# Altium schematic sheet Yamper.SchDoc
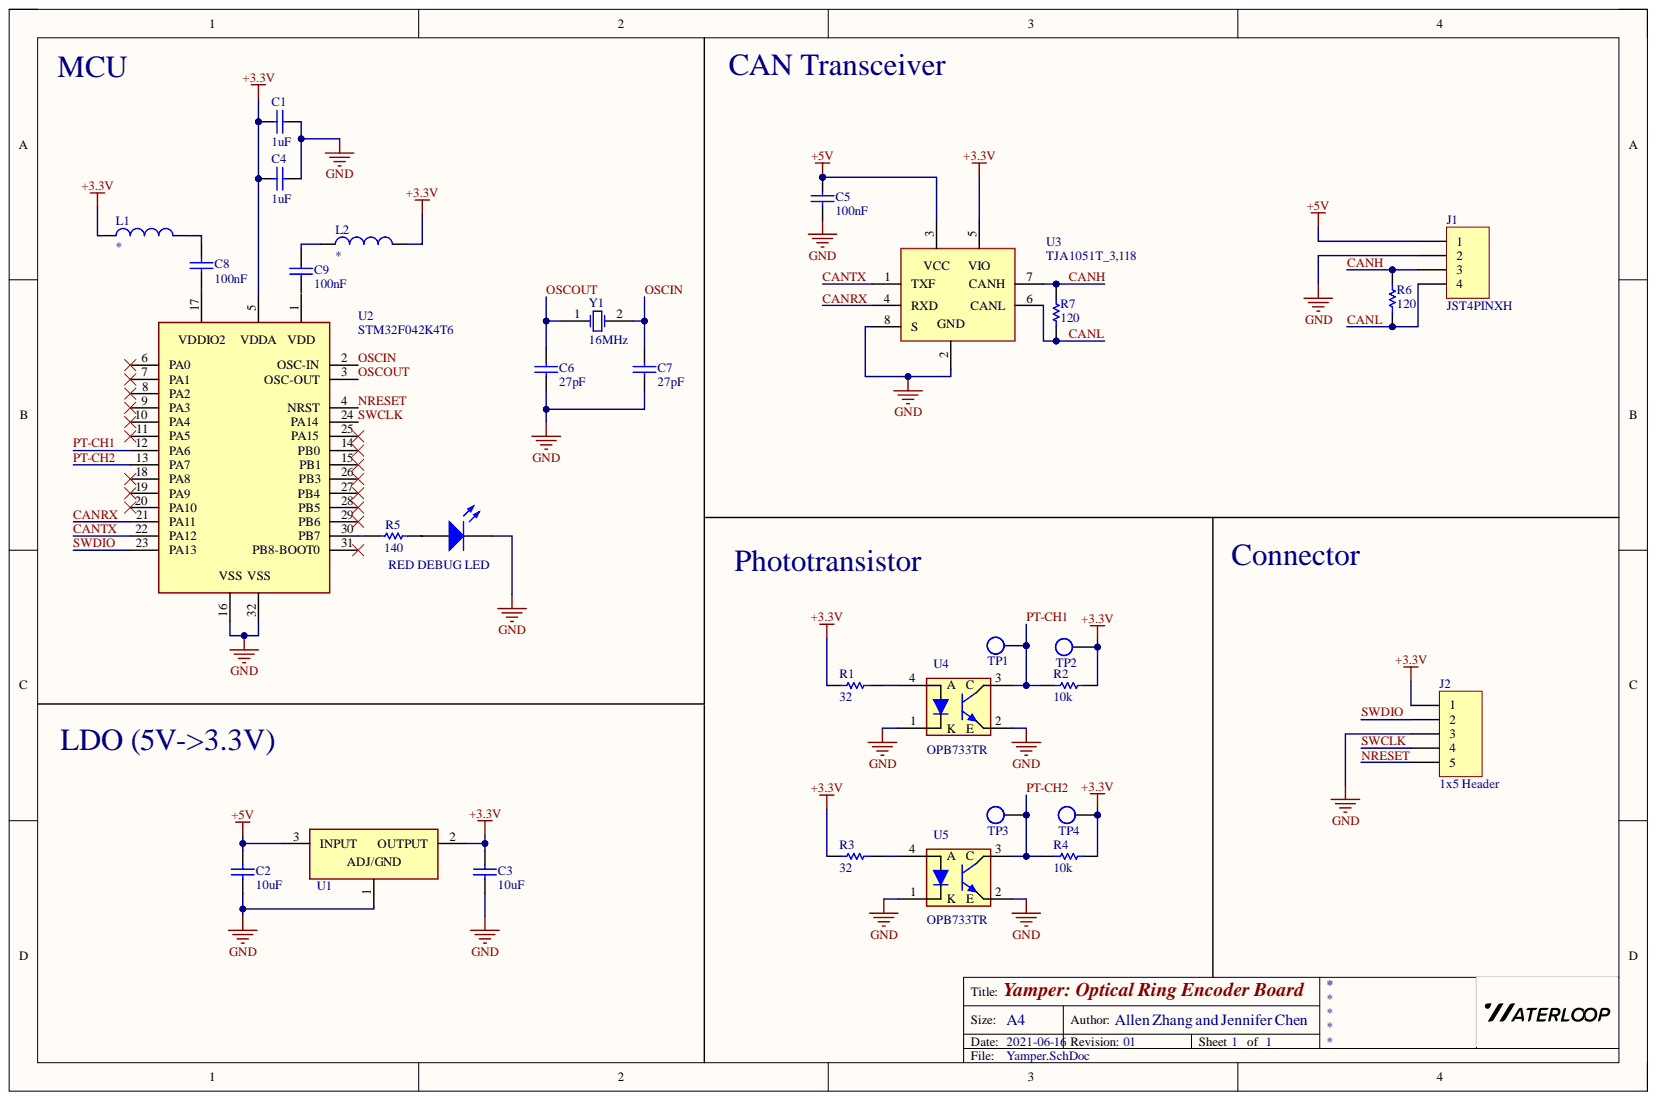
[diagram p0: Yamper.SchDoc]

## Parts
C1  value 1uF  [0603 - Capacitor]  (hidden comment: Capacitor - Nonpolarized)
C2  value 10uF  [0603 - Capacitor]  (hidden comment: Capacitor - Nonpolarized)
C3  value 10uF  [0603 - Capacitor]  (hidden comment: Capacitor - Nonpolarized)
C4  value 1uF  [0603 - Capacitor]  (hidden comment: Capacitor - Nonpolarized)
C5  value 100nF  [0603 - Capacitor]  (hidden comment: Capacitor - Nonpolarized)
C6  value 27pF  [0603 - Capacitor]  (hidden comment: Capacitor - Nonpolarized)
C7  value 27pF  [0603 - Capacitor]  (hidden comment: Capacitor - Nonpolarized)
C8  value 100nF  [0603 - Capacitor]  (hidden comment: Capacitor - Nonpolarized)
C9  value 100nF  [0603 - Capacitor]  (hidden comment: Capacitor - Nonpolarized)
J1  JST4PINXH  [HDR1X4]
J2  1x5 Header  [HDR1X5]
L1  Inductor  [MSS1210 - INDUCTOR]  (value not drawn)
L2  Inductor  [MSS1210 - INDUCTOR]  (value not drawn)
R1  value 32  [0603 - Resistor]  (hidden comment: Resistor)
R2  value 10k  [0603 - Resistor]  (hidden comment: Resistor)
R3  value 32  [0603 - Resistor]  (hidden comment: Resistor)
R4  value 10k  [0603 - Resistor]  (hidden comment: Resistor)
R5  value 140  [0603 - Resistor]  (hidden comment: Resistor)
R6  value 120  [0603 - Resistor]  (hidden comment: Resistor)
R7  value 120  [0603 - Resistor]  (hidden comment: Resistor)
RED_DEBUG_LED  LED
TP1  Test Point  [Test Point]  (value not drawn)  (no BOM)
TP2  Test Point  [Test Point]  (value not drawn)  (no BOM)
TP3  Test Point  [Test Point]  (value not drawn)  (no BOM)
TP4  Test Point  [Test Point]  (value not drawn)  (no BOM)
U1  TLV1117-33IDCYR  [TLV1117]  (value not drawn)
U2  STM32F042K4T6  [QFP80P900X900X160-32N]
U3  TJA1051T_3,118  [TJA1051T/3,118]
U4  OPB733TR
U5  OPB733TR
Y1  value 16MHz  [2-SMD NO LEAD CRSYSTAL]  (hidden comment: Oscillator)

## Nets
+3.3V: C1.1 C3.2 C4.1 J2.1 L1.1 L2.2 R1.1 R2.2 R3.1 R4.2 TP2.1 TP4.1 U1.2 U2.5 U3.5
+5V: C2.1 C5.2 J1.1 U1.3 U3.3
GND: C1.2 C2.2 C3.1 C4.2 C5.1 C6.1 C7.1 J1.2 J2.3 RED_DEBUG_LED.2 U1.1 U2.16 U2.32 U3.2 U3.8(S) U4.1(K) U4.2(E) U5.1(K) U5.2(E)
CANH: J1.3 R6.2 R7.2 U3.7(CANH)
CANL: J1.4 R6.1 R7.1 U3.6(CANL)
CANRX: U2.21(PA11) U3.4(RXD)
CANTX: U2.22(PA12) U3.1(TXF)
NRESET: J2.5 U2.4(NRST)
OSCIN: C7.2 U2.2(OSC-IN) Y1.2
OSCOUT: C6.2 U2.3(OSC-OUT) Y1.1
PT-CH1: R2.1 TP1.1 U2.12(PA6) U4.3(C)
PT-CH2: R4.1 TP3.1 U2.13(PA7) U5.3(C)
SWCLK: J2.4 U2.24(PA14)
SWDIO: J2.2 U2.23(PA13)
NetC8_1: C8.1 U2.17
NetC8_2: C8.2 L1.2
NetC9_2: C9.2 L2.1
NetR1_2: R1.2 U4.4(A)
NetR3_2: R3.2 U5.4(A)
NetR5_1: R5.1 U2.30(PB7)
NetR5_2: R5.2 RED_DEBUG_LED.1

Not connected: C9 1; U2 1 6(PA0) 7(PA1) 8(PA2) 9(PA3) 10(PA4) 11(PA5) 14(PB0) 15(PB1) 18(PA8) 19(PA9) 20(PA10) 25(PA15) 26(PB3) 27(PB4) 28(PB5) 29(PB6) 31(PB8-BOOT0)

## Library data of the parts
C1-C9 = Capacitor - Nonpolarized: Nonpolarized Capacitor; Component Kind: Standard; Library Name: General.SchLib; Signal Integrity: Cap; Simulation: CAP
J1 = 1x4 Header: 1x4 Pin Header; Component Kind: Standard; Library Name: GeneralConnectors.SchLib; Signal Integrity: Connector
J2 = 1x5 Header: 1x5 Pin Header; Component Kind: Standard; Library Name: GeneralConnectors.SchLib; Signal Integrity: Connector
RED_DEBUG_LED = LED: Light Emitting Diode; Component Kind: Standard; Library Name: General.SchLib; Simulation: LED0
U1 = TLV1117-33IDCYR: Linear Voltage Regulator IC 3.3V 800MA SOT223-4; Manufacturer: Texas Instruments; Component Kind: Standard; ComponentLink1Description: Datasheet; ComponentLink1URL: http://www.ti.com/lit/ds/symlink/tlv1117.pdf?HQS=TI-null-null-digikeymode-df-pf-null-wwe&ts=1590668900314; Library Name: GeneralComponents.SchLib; Manufacturer Part Number: TLV1117-33IDCYR; Supplier: Digikey; Supplier Part Number: 296-21112-1-ND
U2 = STM32F042K4T6: Microcontroller; Manufacturer_Name: STMicroelectronics; Component Kind: Standard; ComponentLink1Description: Datasheet; ComponentLink1URL: https://www.mouser.com/datasheet/2/389/stm32f042c4-956225.pdf; Height: 1.6mm; Library Name: Embedded.SchLib; Manufacturer_Part_Number: STM32F042K4T6; Mouser Part Number: 511-STM32F042K4T6; Mouser Price/Stock: https://www.mouser.co.uk/ProductDetail/STMicroelectronics/STM32F042K4T6?qs=ClYTdQWm4hDAfZj5dd9NhA%3D%3D
U3 = TJA1051T_3,118: CAN Transceiver IC 4.5V-5.5V 250ns; Manufacturer: NXP; Component Kind: Standard; ComponentLink1Description: Datasheet; ComponentLink1URL: http://www.nxp.com/docs/en/data-sheet/TJA1051.pdf; Datasheet: http://www.nxp.com/docs/en/data-sheet/TJA1051.pdf; Library Name: GeneralComponents.SchLib; Manufacturer Part Number: TJA1051T/3,118; Supplier: Digikey; Supplier Part Number: 568-8684-1-ND
U4 U5 = OPB733TR: Phototransistor; Component Kind: Standard; Library Name: Embedded.SchLib
Y1 = Oscillator: Crystal Oscillator; Component Kind: Standard; Library Name: General.SchLib; Simulation: XTAL
L1 L2 = Inductor: Component Kind: Standard; Description: Inductor; Library Name: General.SchLib; Signal Integrity: Inductor; Simulation: INDUCTOR
R1-R7 = Resistor: Component Kind: Standard; Description: Resistor; Library Name: General.SchLib; Signal Integrity: Res; Simulation: RESISTOR
TP1-TP4 = Test Point: Description: Test Point; Library Name: General.SchLib
